# Altium schematic sheet IMU_Board.SchDoc
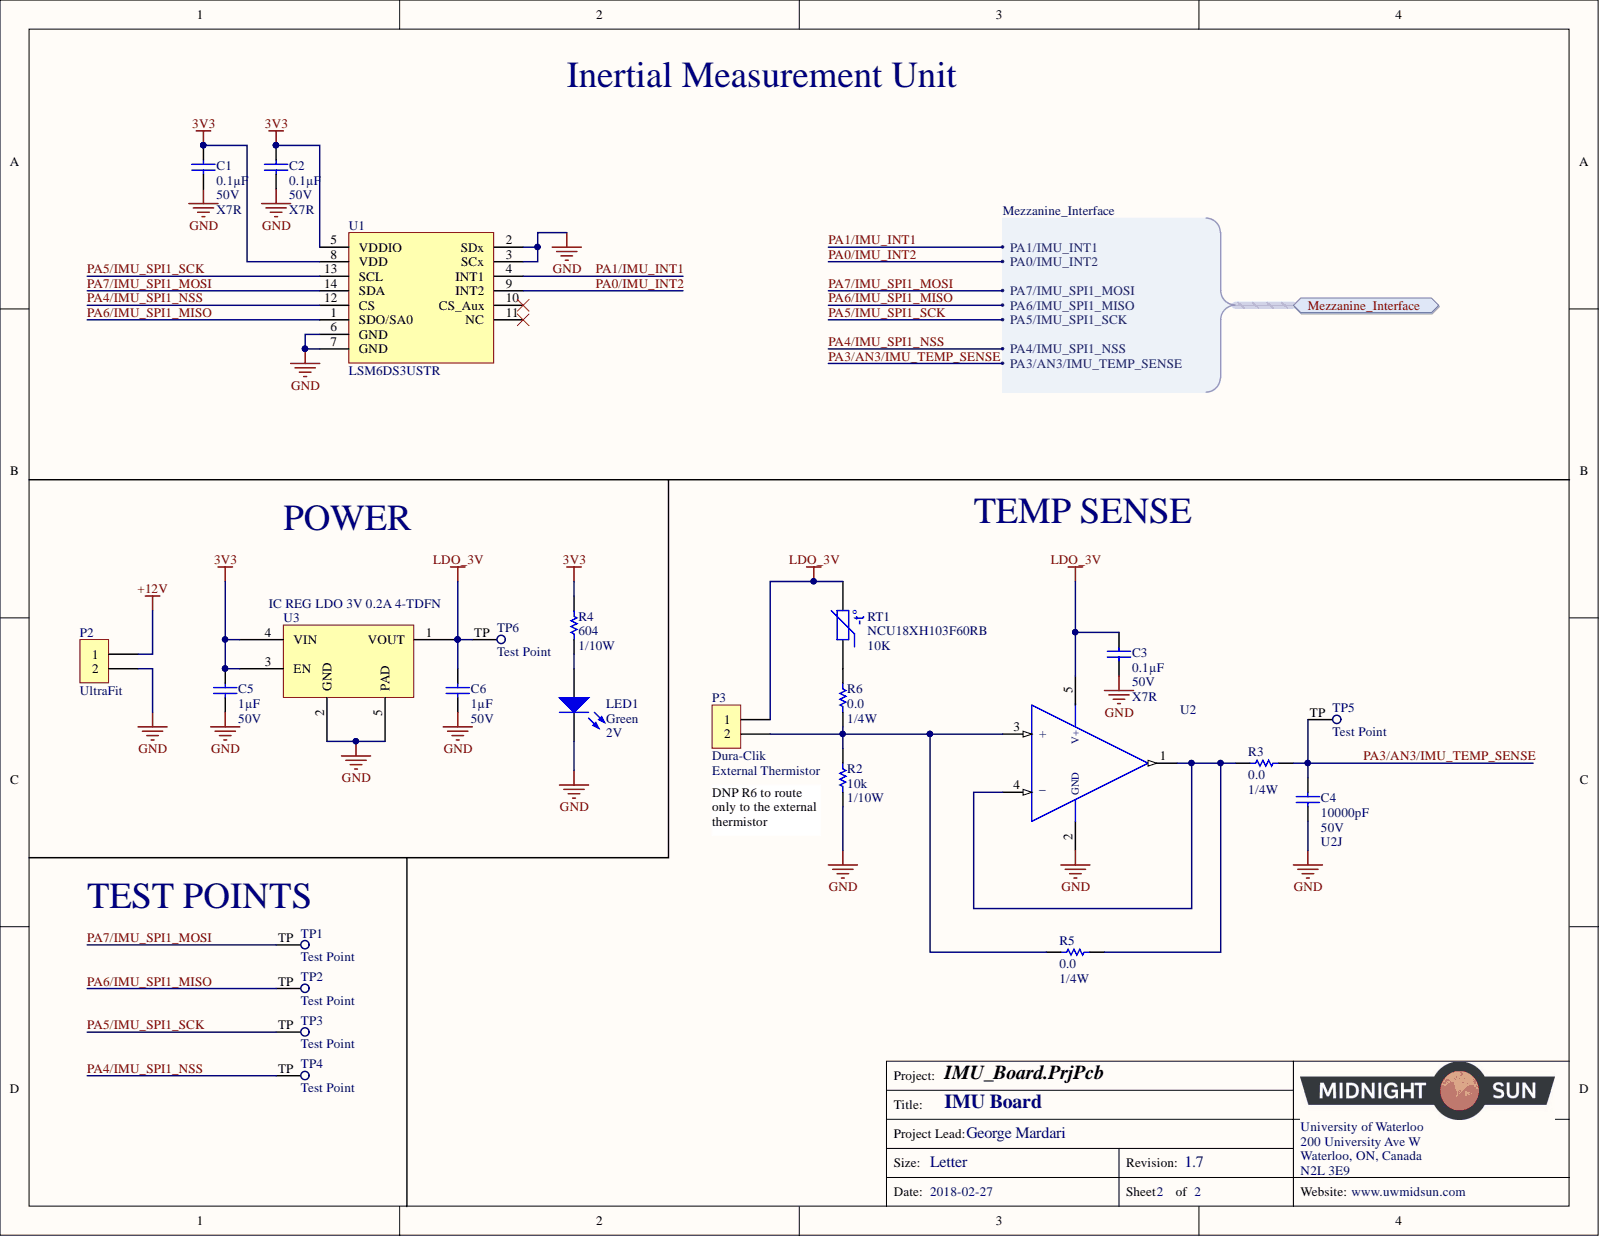
[diagram p0: IMU_Board.SchDoc]

## Parts
C1  Capacitance: 0.1µF  Temperature Coefficient: X7R  Voltage: 50V  [CAP, 0603]  (hidden comment: CAP CER 0.1UF 50V 10% X7R 0603)
C2  Capacitance: 0.1µF  Temperature Coefficient: X7R  Voltage: 50V  [CAP, 0603]  (hidden comment: CAP CER 0.1UF 50V 10% X7R 0603)
C3  Capacitance: 0.1µF  Voltage: 50V  [CAP, 0603]  (hidden comment: CAP CER 0.1UF 50V 10% X7R 0603)
C4  Capacitance: 10000pF  Temperature Coefficient: U2J  Voltage: 50V  [CAP, 0603]  (hidden comment: CAP CER 10nF 50V 5% X7R 0603)
C5  Capacitance: 1µF  Voltage: 50V  [CAP, 0603]  (hidden comment: CAP CER 1UF 50V 10% X7R 0603)
C6  Capacitance: 1µF  Voltage: 50V  [CAP, 0603]  (hidden comment: CAP CER 1UF 50V 10% X7R 0603)
LED1  Color: Green  Voltage - Forward (Vf) (Typ): 2V  [LED_0603]  (hidden comment: LED GREEN CLEAR 2V 0603)
P2  Series: UltraFit  [CONN, 2POS ULTRA-FIT]  (hidden comment: CONN 2POS ULTRA-FIT 0.138")
P3  Dura-Clik  [CONN, 2POS Dura-Click Vertical]
R2  Power: 1/10W  Resistance: 10k  [RES, 0603]  (hidden comment: RES 10K OHM 1% 1/10W 0603)
R3  Power (Watts): 1/4W  Resistance: 0.0  [RES, 0603]  (hidden comment: RES 0.0 OHM 1/4W 0603)
R4  Power: 1/10W  [RES, 0603]  (hidden comment: RES 604 OHM 1% 1/10W 0603)
R5  Power (Watts): 1/4W  Resistance: 0.0  [RES, 0603]  (hidden comment: RES 0.0 OHM 1/4W 0603)  (no BOM)
R6  Power (Watts): 1/4W  Resistance: 0.0  [RES, 0603]  (hidden comment: RES 0.0 OHM 1/4W 0603)
RT1  Manufacturer Part Number: NCU18XH103F60RB  Resistance: 10K  [RES, 0603]  (hidden comment: NTC THERMISTORS 10KOHM 1608 SMD)
TP1  Test Point  [Test Point]
TP2  Test Point  [Test Point]
TP3  Test Point  [Test Point]
TP4  Test Point  [Test Point]
TP5  Test Point  [Test Point]
TP6  Test Point  [Test Point]
U1  Manufacturer Part Number: LSM6DS3USTR  [IC SENSOR IMU LGA-14L]  (hidden comment: IC SENSOR IMU LGA-14L)
U2  IC OP AMP GEN PURPOSE RR 10MHZ SOT-23-5  [IC OP AMP GP RR 10MHZ SOT-23-5]  (value not drawn)
U3  IC REG LDO 3V 0.2A 4-TDFN  Voltage - Output: 3V  [IC REG LDO 3V 0.2A 4-TDFN]

## Ports
Mezzanine_Interface, Mezzanine_Interface.PA0/IMU_INT2, Mezzanine_Interface.PA1/IMU_INT1, Mezzanine_Interface.PA3/AN3/IMU_TEMP_SENSE, Mezzanine_Interface.PA4/IMU_SPI1_NSS, Mezzanine_Interface.PA5/IMU_SPI1_SCK, Mezzanine_Interface.PA6/IMU_SPI1_MISO, Mezzanine_Interface.PA7/IMU_SPI1_MOSI

## Nets
3V3: C1.1 C2.1 C5.1 R4.1 U1.5(VDDIO) U1.8(VDD) U3.3(EN) U3.4(VIN)
+12V: P2.1
GND: C1.2 C2.2 C3.2 C4.2 C5.2 C6.2 LED1.2 P2.2 R2.1 U1.2(SDx) U1.3(SCx) U1.6(GND) U1.7(GND) U2.2 U3.2(GND) U3.5(PAD)
LDO_3V: C3.1 C6.1 P3.1 RT1.2 TP6.TP U2.5 U3.1(VOUT)
PA0/IMU_INT2: U1.9(INT2) ; port Mezzanine_Interface
PA1/IMU_INT1: U1.4(INT1) ; port Mezzanine_Interface
PA3/AN3/IMU_TEMP_SENSE: C4.1 R3.2 TP5.TP ; port Mezzanine_Interface
PA4/IMU_SPI1_NSS: TP4.TP U1.12(CS) ; port Mezzanine_Interface
PA5/IMU_SPI1_SCK: TP3.TP U1.13(SCL) ; port Mezzanine_Interface
PA6/IMU_SPI1_MISO: TP2.TP U1.1(SDO/SA0) ; port Mezzanine_Interface
PA7/IMU_SPI1_MOSI: TP1.TP U1.14(SDA) ; port Mezzanine_Interface
NetLED1_1: LED1.1 R4.2
NetP3_2: P3.2 R2.2 R5.1 R6.2 U2.3(+)
NetR3_1: R3.1 R5.2 U2.1 U2.4
NetR6_1: R6.1 RT1.1

Not connected: U1 10(CS_Aux) 11(NC)

## Library data of the parts
C1-C3 = CAP CER 0.1UF 50V 10% X7R 0603: 0.10µF ±10% 50V Ceramic Capacitor X7R 0603 (1608 Metric); Manufacturer 1: AVX Corporation; Manufacturer Part Number 1: 06035C104KAT2A; Component Kind: Standard; ComponentLink1Description: http://datasheets.avx.com/X7RDielectric.pdf; ComponentLink1URL: http://datasheets.avx.com/X7RDielectric.pdf; Height - Seated (Max): -; Lead Spacing: -; Lead Style: -; Mounting Type: Surface Mount, MLCC; Operating Temperature: -55°C ~ 125°C; Package / Case: 0603 (1608 Metric); Packaging: Cut Tape (CT); Part Status: Active; Ratings: -; Size / Dimension: 0.063" L x 0.032" W (1.60mm x 0.81mm); Supplier 1: Digi-Key; Supplier Part Number 1: 478-5052-1-ND; Thickness (Max): 0.035" (0.90mm); Tolerance: ±10%; Library Name: Schematic Diagrams.SchLib
  C3: Temperature Coefficient: X7R
C4 = CAP CER 10nF 50V 5% X7R 0603: 10000pF ±5% 50V Ceramic Capacitor U2J 0603 (1608 Metric); Manufacturer 1: KEMET; Manufacturer Part Number 1: C0603C103J5JAC7867; Component Kind: Standard; ComponentLink1Description: http://www.kemet.com/docfinder?Partnumber=C0603C103J5JAC7867; ComponentLink1URL: http://www.kemet.com/docfinder?Partnumber=C0603C103J5JAC7867; Failure Rate: -; Height - Seated (Max): -; Lead Spacing: -; Lead Style: -; Mounting Type: Surface Mount, MLCC; Operating Temperature: -55°C ~ 125°C; Package / Case: 0603 (1608 Metric); Packaging: Cut Tape (CT); Part Status: Active; Ratings: -; Size / Dimension: 0.063" L x 0.032" W (1.60mm x 0.80mm); Supplier 1: Digi-Key; Supplier Part Number 1: 399-13384-1-ND; Thickness (Max): 0.034" (0.87mm); Tolerance: ±5%; Library Name: Schematic Diagrams.SchLib
C5 C6 = CAP CER 1UF 50V 10% X7R 0603: 1µF ±10% 50V Ceramic Capacitor X7R 0603 (1608 Metric); Manufacturer 1: Taiyo Yuden; Manufacturer Part Number 1: UMK107AB7105KA-T; Component Kind: Standard; ComponentLink1Description: http://www.yuden.co.jp/productdata/catalog/en/mlcc_all_e.pdf; ComponentLink1URL: http://www.yuden.co.jp/productdata/catalog/en/mlcc_all_e.pdf; Height - Seated (Max): -; Lead Spacing: -; Lead Style: -; Mounting Type: Surface Mount, MLCC; Operating Temperature: -55°C ~ 125°C; Package / Case: 0603 (1608 Metric); Packaging: Cut Tape (CT); Part Status: Active; Ratings: -; Size / Dimension: 0.063" L x 0.031" W (1.60mm x 0.80mm); Supplier 1: Digi-Key; Supplier Part Number 1: 587-3247-1-ND; Thickness (Max): 0.037" (0.95mm); Tolerance: ±10%; Library Name: Schematic Diagrams.SchLib
LED1 = LED GREEN CLEAR 2V 0603: Green 572nm LED Indication - Discrete 2V 0603 (1608 Metric); Manufacturer: Wurth Electronics Inc.; Manufacturer Part Number: 150060VS75000; Current - Test: 20mA; Lens Color: Colorless; Lens Style/Size: Rectangle with Flat Top, 1.10mm x 0.70mm; Millicandela Rating: 40mcd; Viewing Angle: 140°; Wavelength - Dominant: 570nm; Category: Optoelectronics; Component Kind: Standard; ComponentLink1Description: http://katalog.we-online.de/led/datasheet/150060VS75000.pdf; ComponentLink1URL: http://katalog.we-online.de/led/datasheet/150060VS75000.pdf; Features: -; Height (Max): 0.80mm; Lens Transparency: Clear; Mounting Type: Surface Mount; Package / Case: 0603 (1608 Metric); Packaging: Cut Tape (CT); Part Status: Active; Pricing 1: 1=0.21, 100=0.1617, 500=0.13964 (CAD); RoHS: RoHS Compliant; Size / Dimension: 1.60mm L x 0.80mm W; Stock 1: 9408; Supplier 1: Digi-Key; Supplier Device Package: 0603; Supplier Part Number 1: 732-4980-1-ND; Wavelength - Peak: 572nm; Library Name: Schematic Diagrams.SchLib
P2 = CONN 2POS ULTRA-FIT 0.138": 2 Positions Header, Shrouded Connector 0.138" (3.50mm) Through Hole Gold; Manufacturer 1: Molex, LLC; Manufacturer Part Number 1: 1722861302; Contact Length - Post: 0.126" (3.20mm); Mated Stacking Heights: 26.99mm; Material Flammability Rating: UL94 V-0; Number of Positions: 2; Number of Positions Loaded: All; Shrouding: Shrouded - 4 Wall; Style: Board to Board or Cable; Voltage: 400V; Component Kind: Standard; ComponentLink1Description: http://www.molex.com/pdm_docs/sd/1722861302_sd.pdf; ComponentLink1URL: http://www.molex.com/pdm_docs/sd/1722861302_sd.pdf; Connector Type: Header; Contact Finish - Mating: Gold; Contact Finish - Post: Tin; Contact Finish Thickness - Mating: 30µin (0.76µm); Contact Finish Thickness - Post: 60µin (1.52µm); Contact Length - Mating: -; Contact Material: Copper Alloy; Contact Shape: Square; Contact Type: Male Pin; Current Rating: -; Fastening Type: Latch Holder; Ingress Protection: -; Insulation Color: Black; Insulation Height: 0.465" (11.80mm); Insulation Material: Liquid Crystal Polymer (LCP); Mounting Type: Through Hole; Number of Rows: 1; Operating Temperature: -40°C ~ 120°C; Overall Contact Length: -; Packaging: Tray; Part Status: Active; Pitch - Mating: 0.138" (3.50mm); Row Spacing - Mating: -; Supplier 1: Digi-Key; Supplier Part Number 1: WM11673-ND; Termination: Kinked Pin, Solder; Library Name: Schematic Diagrams.SchLib
P3 = CONN 2POS DURA-CLIK 0.079" VERT: 2 Positions Header, Shrouded Connector 0.079" (2.00mm) Surface Mount, Vertical Tin; Manufacturer: Molex, LLC; Manufacturer Part Number: 5600200220; Mated Stacking Heights: 9.3mm; Number of Positions: 2; Number of Positions Loaded: All; Shrouding: Shrouded - 4 Wall; Style: Board to Cable/Wire; Applications: Automotive, Industrial; Category: Connectors, Interconnects; Component Kind: Standard; ComponentLink1Description: http://www.molex.com/pdm_docs/sd/5600200220_sd.pdf; ComponentLink1URL: http://www.molex.com/pdm_docs/sd/5600200220_sd.pdf; Connector Type: Header; Contact Finish - Mating: Tin; Contact Finish - Post: Tin; Contact Finish Thickness - Mating: -; Contact Finish Thickness - Post: -; Contact Length - Mating: -; Contact Length - Post: -; Contact Material: Copper Alloy; Contact Shape: Square; Contact Type: Male Pin; Current Rating: -; Fastening Type: Latch Lock; Features: Solder Retention; Ingress Protection: -; Insulation Color: Natural; Insulation Height: 0.315" (8.00mm); Insulation Material: Polyamide (PA), Nylon; Material Flammability Rating: -; Mounting Type: Surface Mount; Number of Rows: 1; Operating Temperature: -; Overall Contact Length: -; Packaging: Cut Tape (CT); Part Status: Active; Pitch - Mating: 0.079" (2.00mm); Pricing 1: 1=1.16, 10=1.012, 25=0.952, 50=0.9122, 100=0.8727, 250=0.79336 (CAD); RoHS: RoHS Compliant; Row Spacing - Mating: -; Stock 1: 11912; Supplier 1: Digi-Key; Supplier Part Number 1: WM10862CT-ND; Termination: Solder; Voltage Rating: -; Library Name: Schematic Diagrams.SchLib
R2 = RES 10K OHM 1% 1/10W 0603: RES SMD 10K OHM 1% 1/10W 0603; Manufacturer 1: Yageo; Manufacturer Part Number 1: RC0603FR-0710KL; Temperature Coefficient: ±100ppm/°C; Component Kind: Standard; ComponentLink1Description: http://www.yageo.com/documents/recent/PYu-RC_Group_51_RoHS_L_7.pdf; ComponentLink1URL: http://www.yageo.com/documents/recent/PYu-RC_Group_51_RoHS_L_7.pdf; Composition: Thick Film; Failure Rate: -; Height - Seated (Max): 0.022" (0.55mm); Operating Temperature: -55°C ~ 155°C; Package / Case: 0603 (1608 Metric); Packaging: Cut Tape (CT); Part Status: Active; Size / Dimension: 0.063" L x 0.031" W (1.60mm x 0.80mm); Supplier 1: Digi-Key; Supplier Device Package: 0603; Supplier Part Number 1: 311-10.0KHRCT-ND; Tolerance: ±1%; Library Name: Schematic Diagrams.SchLib
R3 R5 R6 = RES 0.0 OHM 1/4W 0603: RES SMD 0.0 OHM JUMPER 1/4W 0603; Manufacturer 1: Vishay Dale; Manufacturer Part Number 1: CRCW06030000Z0EAHP; ComponentLink1Description: http://www.vishay.com/docs/20043/crcwhpe3.pdf; ComponentLink1URL: http://www.vishay.com/docs/20043/crcwhpe3.pdf; Composition: Thick Film; Failure Rate: -; Height - Seated (Max): 0.022" (0.55mm); Operating Temperature: -55°C ~ 155°C; Package / Case: 0603 (1608 Metric); Packaging: Cut Tape (CT); Part Status: Active; Size / Dimension: 0.063" L x 0.033" W (1.60mm x 0.85mm); Supplier 1: Digi-Key; Supplier Device Package: 0603; Supplier Part Number 1: 541-0.0SBCT-ND; Library Name: Schematic Diagrams.SchLib
  R3 R6: Component Kind: Standard
R4 = RES 604 OHM 1% 1/10W 0603: RES SMD 604 OHM 1% 1/10W 0603; Manufacturer 1: Yageo; Manufacturer Part Number 1: RC0603FR-07604RL; Resistance: 604; Temperature Coefficient: ±100ppm/°C; Component Kind: Standard; ComponentLink1Description: http://www.yageo.com/documents/recent/PYu-RC_Group_51_RoHS_L_7.pdf; ComponentLink1URL: http://www.yageo.com/documents/recent/PYu-RC_Group_51_RoHS_L_7.pdf; Composition: Thick Film; Failure Rate: -; Height - Seated (Max): 0.022" (0.55mm); Operating Temperature: -55°C ~ 155°C; Package / Case: 0603 (1608 Metric); Packaging: Cut Tape (CT); Part Status: Active; Size / Dimension: 0.063" L x 0.031" W (1.60mm x 0.80mm); Supplier 1: Digi-Key; Supplier Device Package: 0603; Supplier Part Number 1: 311-604HRCT-ND; Tolerance: ±1%; Library Name: Schematic Diagrams.SchLib
RT1 = NTC THERMISTORS 10KOHM 1608 SMD: Manufacturer: Murata Electronics North America; B25/50: 3380K; B25/85: 3434K; B25/100: 3455K; B Value Tolerance: ±1%; B0/50: -; B25/75: -; Category: Sensors, Transducers; Component Kind: Standard; ComponentLink1Description: https://www.murata.com/~/media/webrenewal/support/library/catalog/products/thermistor/r03e.ashx; ComponentLink1URL: https://www.murata.com/~/media/webrenewal/support/library/catalog/products/thermistor/r03e.ashx; Description: NTC THERMISTORS 10KOHM 1608 SMD; Length - Lead Wire: -; Mounting Type: Surface Mount; Operating Temperature: -40°C ~ 125°C; Package / Case: 0603 (1608 Metric); Packaging: Tape & Reel (TR); Part Status: Active; Pricing 1: 4000=0.06734, 8000=0.05942, 12000=0.05744, 20000=0.05645, 28000=0.05546 (CAD); RoHS: Request Inventory Verification; Stock 1: 4000; Supplier 1: Digi-Key; Library Name: Schematic Diagrams.SchLib
U1 = IC SENSOR IMU LGA-14L: Accelerometer, Gyroscope, 3 Axis Sensor I²C, SPI Output; Manufacturer: STMicroelectronics; Category: Sensors, Transducers; Component Kind: Standard; ComponentLink1Description: http://www.st.com/content/ccc/resource/technical/document/datasheet/group0/2e/84/27/34/60/8d/49/f2/DM00237513/files/DM00237513.pdf/jcr:content/translations/en.DM00237513.pdf; ComponentLink1URL: http://www.st.com/content/ccc/resource/technical/document/datasheet/group0/2e/84/27/34/60/8d/49/f2/DM00237513/files/DM00237513.pdf/jcr:content/translations/en.DM00237513.pdf; Operating Temperature: -40°C ~ 85°C (TA); Output Type: I²C, SPI; Part Status: Active; Pricing 1: 1=4.3, 10=3.858, 100=3.1606, 500=2.69052, 1000=2.26912 (CAD); RoHS: RoHS Compliant; Sensor Type: Accelerometer, Gyroscope, 3 Axis; Stock 1: 23614; Supplier 1: Digi-Key; Supplier Part Number 1: 497-16910-1-ND; Library Name: Schematic Diagrams.SchLib
U2 = IC OP AMP GEN PURPOSE RR 10MHZ SOT-23-5: General Purpose Amplifier 1 Circuit Rail-to-Rail SOT-23-5; Manufacturer 1: Texas Instruments; Manufacturer Part Number 1: TLV316QDBVRQ1; Current - Output / Channel: 50mA; Current - Supply: 400µA; Description 1: IC OP AMP GP RR 10MHZ 5-SOT-23; Number of Circuits: 1; Slew Rate: 6 V/µs; Voltage - Supply, Single/Dual (±): 1.8 V ~ 5.5 V, ±0.9 V ~ 2.75 V; -3db Bandwidth: -; Amplifier Type: General Purpose; Category: Integrated Circuits (ICs); Component Kind: Standard; ComponentLink1Description: http://www.ti.com/lit/ds/symlink/tlv316-q1.pdf; ComponentLink1URL: http://www.ti.com/lit/ds/symlink/tlv316-q1.pdf; Mounting Type: Surface Mount; Operating Temperature: -40°C ~ 125°C; Output Type: Rail-to-Rail; Package / Case: SC-74A, SOT-753; Packaging: Cut Tape (CT); Part Status: Active; Pricing 1: 1=1.33, 10=1.185, 100=0.9234, 500=0.76276, 1000=0.60218 (CAD); RoHS: RoHS Compliant; Stock 1: 1747; Supplier 1: Digi-Key; Supplier Device Package: SOT-23-5; Supplier Part Number 1: 296-45323-1-ND; Library Name: Schematic Diagrams.SchLib
U3 = IC REG LDO 3V 0.2A 4-TDFN: Manufacturer: Microchip Technology; Manufacturer Part Number: MIC94310-PYMT-TR; Current - Limit (Min): 250mA; Current - Output: 200mA; Regulator Topology: Positive Fixed; Voltage - Dropout (Typical): 0.04V @ 200mA; Voltage - Input: Up to 3.6V; Category: Integrated Circuits (ICs); Component Kind: Standard; ComponentLink1Description: http://www.microchip.com/mymicrochip/filehandler.aspx?ddocname=en580409; ComponentLink1URL: http://www.microchip.com/mymicrochip/filehandler.aspx?ddocname=en580409; Mounting Type: Surface Mount; Operating Temperature: -40°C ~ 125°C; Package / Case: 4-UFDFN Exposed Pad; Packaging: Cut Tape (CT); Part Status: Active; Pricing 1: 1=0.45, 25=0.3708, 100=0.3386 (CAD); RoHS: RoHS Compliant; Stock 1: 4802; Supplier 1: Digi-Key; Supplier Device Package: 4-TDFN (1.2x1.6); Library Name: Schematic Diagrams.SchLib
TP1-TP6 = Test Point: Component Kind: Standard; Library Name: Schematic Diagrams.SchLib
